# Altium schematic sheet I2S_Shifters.SchDoc
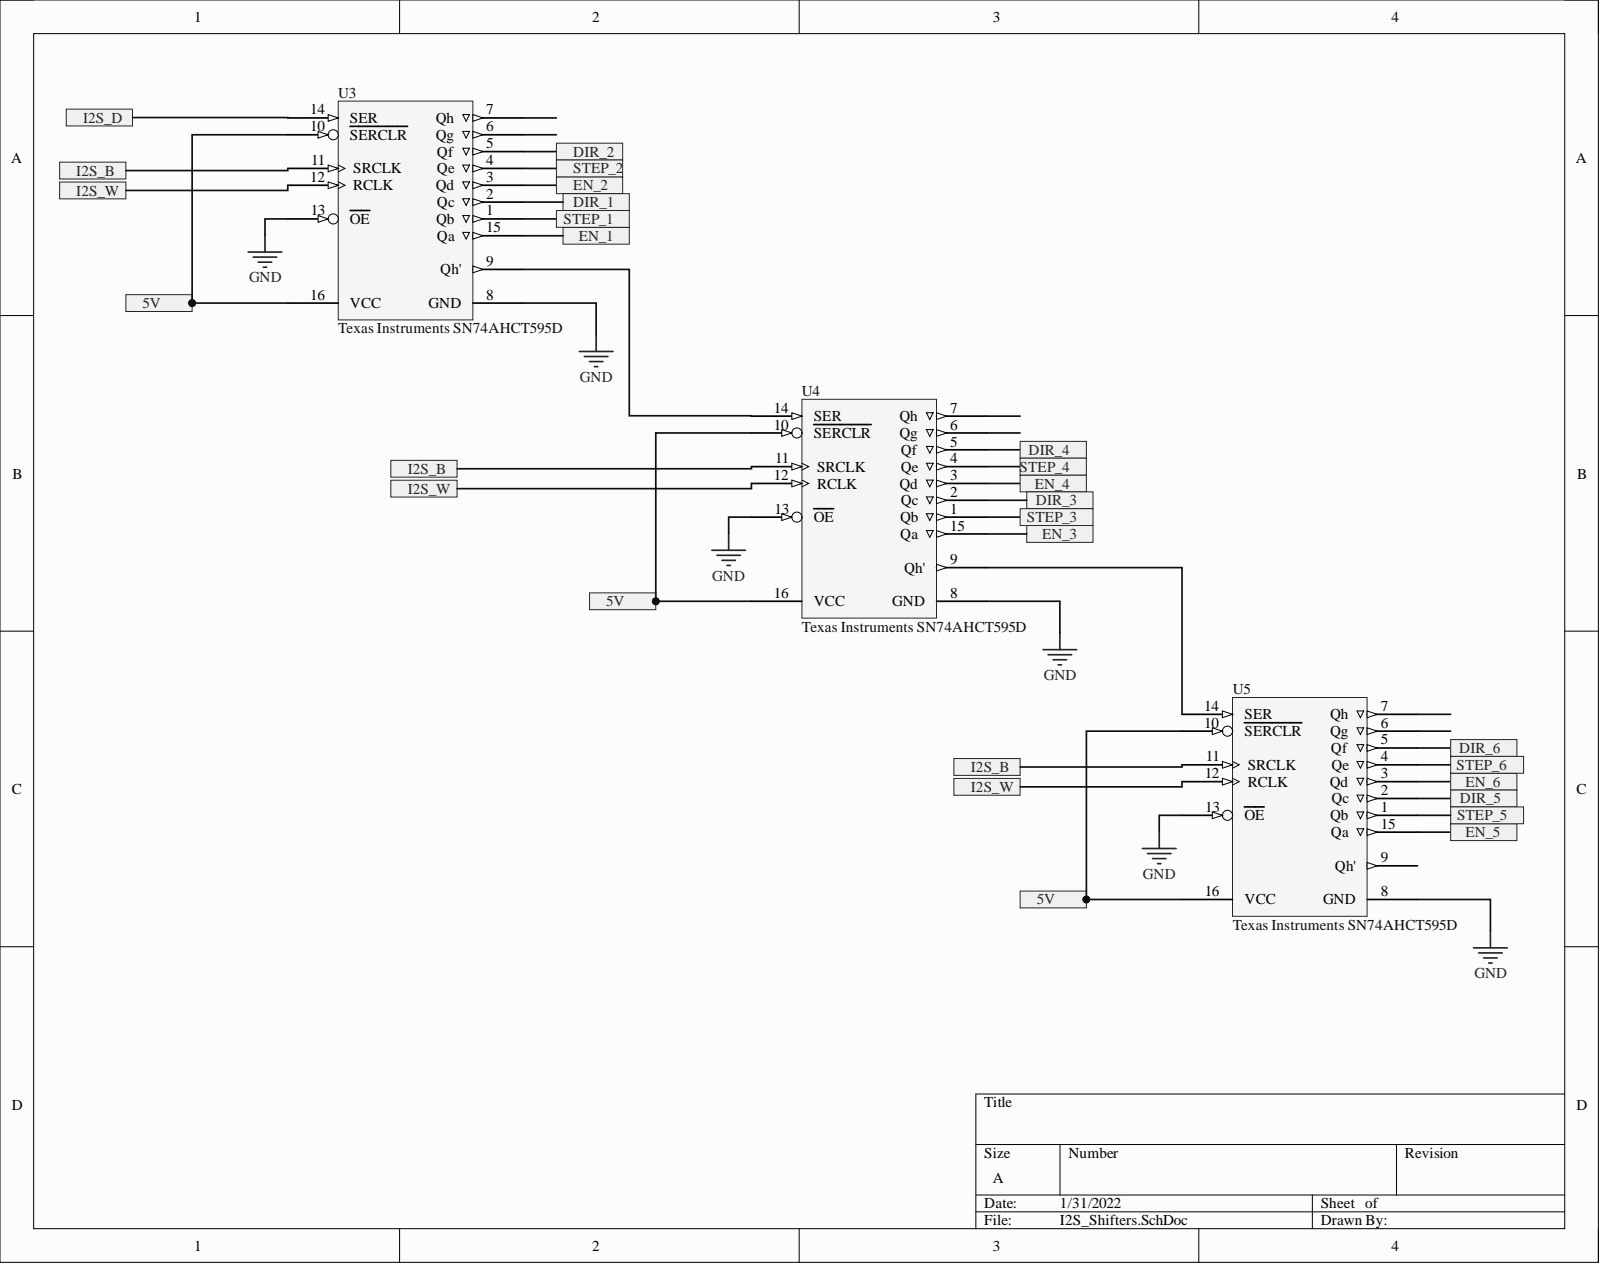
[diagram p0: I2S_Shifters.SchDoc]

## Parts
U3  Texas Instruments SN74AHCT595D  Manufacturer Part Number: SN74AHCT595D  [PCB-u51jluiokpefud6e4fih-1]
U4  Texas Instruments SN74AHCT595D  Manufacturer Part Number: SN74AHCT595D  [PCB-u51jluiokpefud6e4fih-1]
U5  Texas Instruments SN74AHCT595D  Manufacturer Part Number: SN74AHCT595D  [PCB-u51jluiokpefud6e4fih-1]

## Ports
5V, DIR_1, DIR_2, DIR_3, DIR_4, DIR_5, DIR_6, EN_1, EN_2, EN_3, EN_4, EN_5, EN_6, I2S_B, I2S_D, I2S_W, STEP_1, STEP_2, STEP_3, STEP_4, STEP_5, STEP_6

## Nets
GND: U3.8(GND) U3.13(OE) U4.8(GND) U4.13(OE) U5.8(GND) U5.13(OE)
5V: U3.10(SERCLR) U3.16(VCC) U4.10(SERCLR) U4.16(VCC) U5.10(SERCLR) U5.16(VCC) ; port 5V
DIR_1: U3.2(Qc) ; port DIR_1
DIR_2: U3.5(Qf) ; port DIR_2
DIR_3: U4.2(Qc) ; port DIR_3
DIR_4: U4.5(Qf) ; port DIR_4
DIR_5: U5.2(Qc) ; port DIR_5
DIR_6: U5.5(Qf) ; port DIR_6
EN_1: U3.15(Qa) ; port EN_1
EN_2: U3.3(Qd) ; port EN_2
EN_3: U4.15(Qa) ; port EN_3
EN_4: U4.3(Qd) ; port EN_4
EN_5: U5.15(Qa) ; port EN_5
EN_6: U5.3(Qd) ; port EN_6
I2S_B: U3.11(SRCLK) U4.11(SRCLK) U5.11(SRCLK) ; port I2S_B
I2S_D: U3.14(SER) ; port I2S_D
I2S_W: U3.12(RCLK) U4.12(RCLK) U5.12(RCLK) ; port I2S_W
STEP_1: U3.1(Qb) ; port STEP_1
STEP_2: U3.4(Qe) ; port STEP_2
STEP_3: U4.1(Qb) ; port STEP_3
STEP_4: U4.4(Qe) ; port STEP_4
STEP_5: U5.1(Qb) ; port STEP_5
STEP_6: U5.4(Qe) ; port STEP_6
NetU3_9: U3.9(Qh') U4.14(SER)
NetU4_9: U4.9(Qh') U5.14(SER)

Not connected: U3 6(Qg) 7(Qh); U4 6(Qg) 7(Qh); U5 6(Qg) 7(Qh) 9(Qh')

## Library data of the parts
U3-U5 = CMP-13610480-8: Shift Register Single 8-Bit Serial to Serial/Parallel 16-Pin SOIC Tube; Frequency: 170 MHz; Lead-Free Status: Lead Free; Number of Bits: 8; Supply Voltage (DC): 5.00 V, 5.50 V (max); Voltage Nodes: 5.00 V; Case/Package: SOIC; Component Kind: Standard; Library Name: CommunityVault; Lifecycle Status: Active; Mounting Style: Surface Mount; Number of Pins: 16; Octopart URL: http://octopart.com/sn74ahct595d-texas+instruments-500622; OctopartID: 6ed9a7d4e831b11e; Packaging: Tube; REACH SVHC Compliance: No SVHC; RoHS: Compliant
